# Altium schematic sheet SFP_SATA.SchDoc(SFP_SATA)
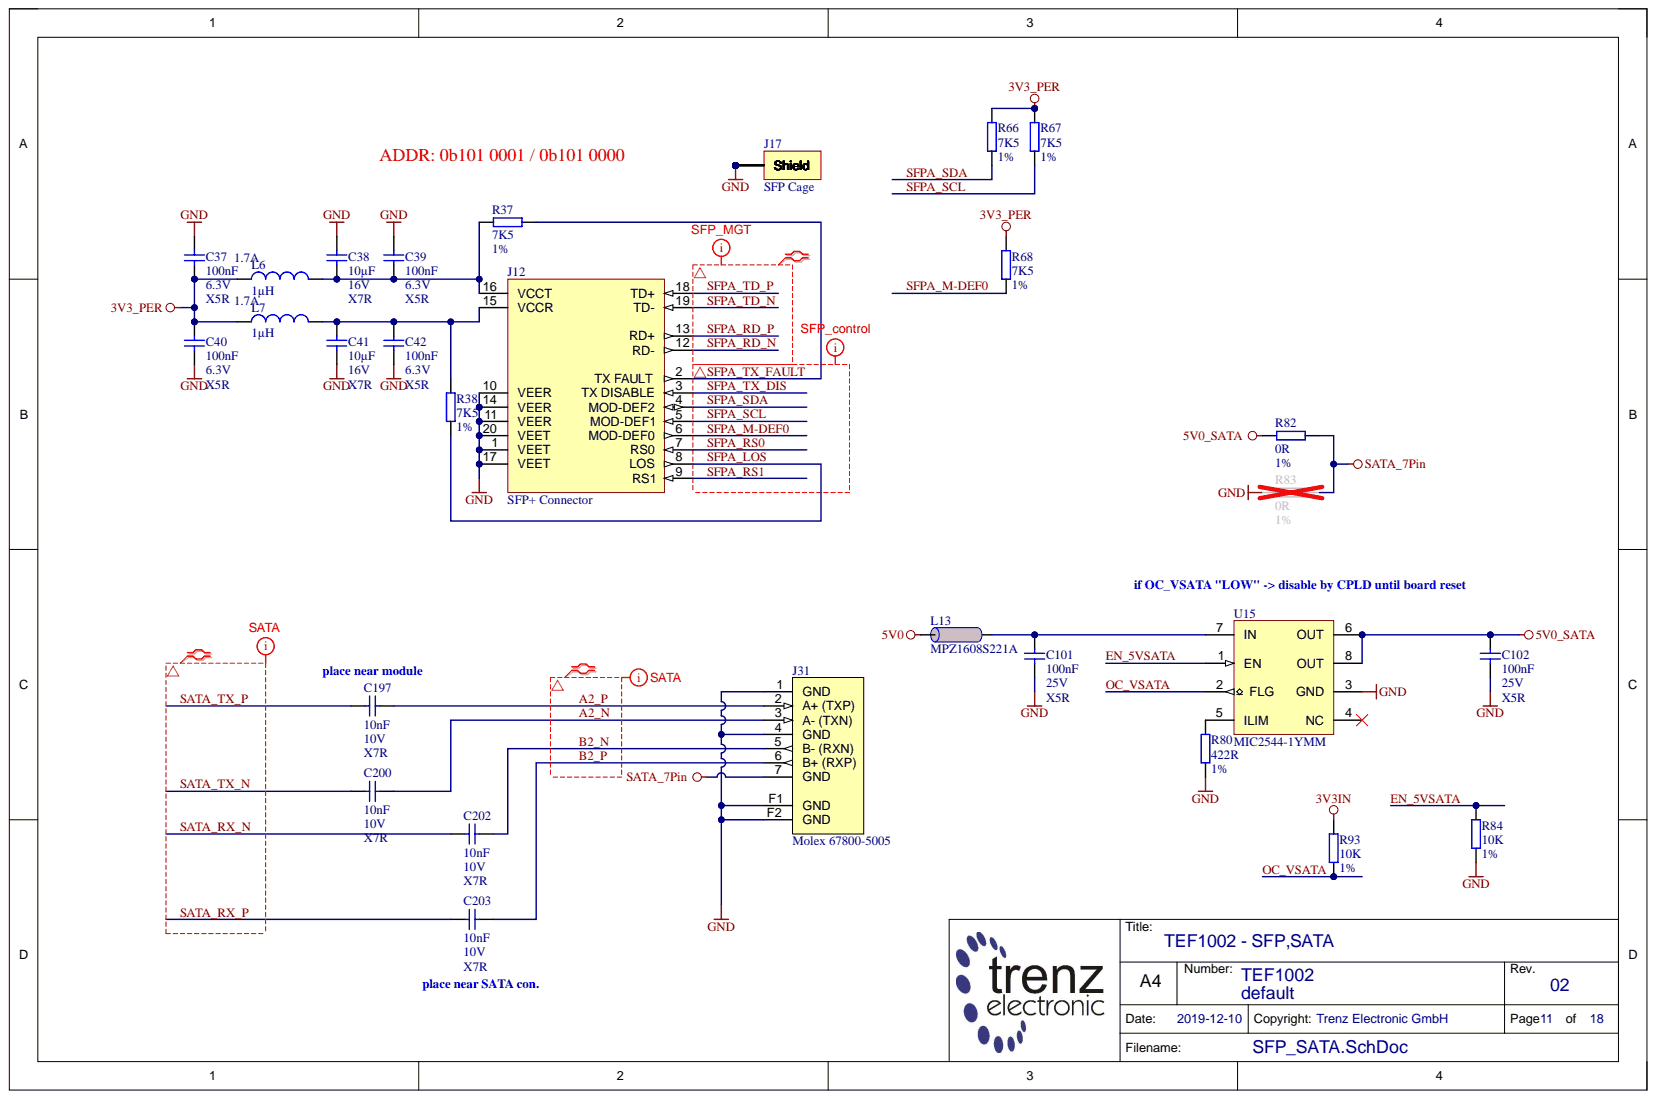
[diagram p0: SFP_SATA.SchDoc]

## Parts
C37  100nF  Technology: X5R  Voltage: 6.3V  [CAPC0603X33N]
C38  10µF  Technology: X7R  Voltage: 16V  [CAPC2012X135N]
C39  100nF  Technology: X5R  Voltage: 6.3V  [CAPC0603X33N]
C40  100nF  Technology: X5R  Voltage: 6.3V  [CAPC0603X33N]
C41  10µF  Technology: X7R  Voltage: 16V  [CAPC2012X135N]
C42  100nF  Technology: X5R  Voltage: 6.3V  [CAPC0603X33N]
C101  100nF  Technology: X5R  Voltage: 25V  [CAPC1005X55N]
C102  100nF  Technology: X5R  Voltage: 25V  [CAPC1005X55N]
C197  10nF  Voltage: 10V  [CAPC0603X33N]
C200  10nF  Technology: X7R  Voltage: 10V  [CAPC0603X33N]
C202  10nF  Voltage: 10V  [CAPC0603X33N]
C203  10nF  Technology: X7R  Voltage: 10V  [CAPC0603X33N]
J12  SFP+ Connector  [SFP Connector]
J17  SFP Cage  [SFP Cage]
J31  Molex 67800-5005  [67800-5005]
L6  1µH  Voltage: 1.7A  [INDC2520X100N]
L7  1µH  Voltage: 1.7A  [INDC2520X100N]
L13  MPZ1608S221A  [INDC1608X95N]
R37  7K5  [RESC1005X40N]
R38  7K5  Tolerance: 1%  [RESC1005X40N]
R66  7K5  Tolerance: 1%  [RESC1005X40N]
R67  7K5  Tolerance: 1%  [RESC1005X40N]
R68  7K5  Tolerance: 1%  [RESC1005X40N]
R80  422R  Tolerance: 1%  [RESC1005X40N]
R82  0R  [RESC2012X60N]
R83  0R  Tolerance: 1%  [RESC2012X60N]
R84  10K  Tolerance: 1%  [RESC1005X40N]
R93  10K  Tolerance: 1%  [RESC1005X40N]
U15  MIC2544-1YMM  [SOP65P490X110-8N]

## Nets
3V3_PER: C37.2 C40.1 L6.1 L7.1 R66.1 R67.1 R68.1
3V3IN: R93.2
5V0: L13.2
5V0_SATA: C102.2 R82.1 U15.6(OUT) U15.8(OUT)
GND: C37.1 C38.1 C39.1 C40.2 C41.2 C42.2 C101.1 C102.1 J12.1(VEET) J12.10(VEER) J12.11(VEER) J12.14(VEER) J12.17(VEET) J12.20(VEET) J17.C1(Shield) J17.C2 J17.C3 J17.C4 J17.C5 J17.C6 J17.C7 J17.C8 J17.C9 J17.C10 J17.C11 J17.C12 J17.C13 J17.C14 J17.C15 J17.C16 J17.C17 J17.C18 J17.C19 J17.C20 J31.1(GND) J31.4(GND) J31.F1(GND) J31.F2(GND) R80.2 R83.1 R84.2 U15.3(GND)
SATA_7Pin: J31.7(GND) R82.2 R83.2
A2_N: C200.2 J31.3(A-_(TXN))
A2_P: C197.2 J31.2(A+_(TXP))
B2_N: C202.2 J31.5(B-_(RXN))
B2_P: C203.2 J31.6(B+_(RXP))
EN_5VSATA: R84.1 U15.1(EN)
OC_VSATA: R93.1 U15.2(FLG)
SATA_RX_N: C202.1
SATA_RX_P: C203.1
SATA_TX_N: C200.1
SATA_TX_P: C197.1
SFPA_LOS: J12.8(LOS) R38.2
SFPA_M-DEF0: J12.6(MOD-DEF0) R68.2
SFPA_RD_N: J12.12(RD-)
SFPA_RD_P: J12.13(RD+)
SFPA_RS0: J12.7(RS0)
SFPA_RS1: J12.9(RS1)
SFPA_SCL: J12.5(MOD-DEF1) R67.2
SFPA_SDA: J12.4(MOD-DEF2) R66.2
SFPA_TD_N: J12.19(TD-)
SFPA_TD_P: J12.18(TD+)
SFPA_TX_DIS: J12.3(TX_DISABLE)
SFPA_TX_FAULT: J12.2(TX_FAULT) R37.2
NetC38_2: C38.2 C39.2 J12.16(VCCT) L6.2 R37.1
NetC41_1: C41.1 C42.1 J12.15(VCCR) L7.2 R38.1
NetC101_2: C101.2 L13.1 U15.7(IN)
NetR80_1: R80.1 U15.5(ILIM)

Not connected: U15 4(NC)

## Library data of the parts
C37 C39 C40 C42 = 24915: KON 100nF 6.3V X5R 10% SMD BF 0603 (0201); Database Table Name: C_0603_0201; Mixed: SMT; Preferred: Yes; Temperature-Range: -55/+85; Value: 100n; AN: 24915; Case: 0603 (0201); Component Kind: Standard; Library Name: TE.DbLib; Tolerance: 10%
C38 C41 = 24380: KON 10µF 16V X7R 10% SMD BF 2012 (0805); Database Table Name: C_2012_0805; Mixed: SMT; Preferred: Yes; Temperature-Range: -55/+125; Value: 10u; AN: 24380; Case: 2012 (0805); Component Kind: Standard; Library Name: TE.DbLib; Tolerance: 10%
C101 C102 = 22472: KON 100nF 25V X5R ±10% SMD BF 1005 (0402); Database Table Name: C_1005_0402; Mixed: SMT; Preferred: Yes; Temperature-Range: -55/+85; Value: 100n; AN: 22472; Case: 1005 (0402); Component Kind: Standard; Library Name: TE.DbLib
C197 C200 C202 C203 = 26312: KON 10nF 10V X7R 10% SMD BF 0603 (0201); Database Table Name: C_0603_0201; Mixed: SMT; Preferred: Yes; Temperature-Range: -55/+125; Value: 10n; AN: 26312; Case: 0603 (0201); Component Kind: Standard; Library Name: TE.DbLib; Tolerance: 10%
  C197 C202: Technology: X7R
J12 = 25303: STE SFP+ Connector 20 pol. SMD; Database Table Name: CON_IO; H-ART: 74441-0001; Mixed: SMT; Trenz-Stock: Yes; AN: 25303; Component Kind: Standard; Library Name: TE.DbLib; HelpURL: B:\AD\adlib\datasheet\SFPP
J17 = 25302: STE SFP Cage Pressfit; Database Table Name: CON_IO; H-ART: 74737-0009; Mixed: MECH; Trenz-Stock: Yes; AN: 25302; Component Kind: Standard; Library Name: TE.DbLib; HelpURL: B:\AD\adlib\datasheet\747370009
J31 = 25614: SATA 7Pol. Connector Vertical; Database Table Name: CON_IO; Mixed: SMT; AN: 25614; Component Kind: Standard; H-ART: 67800-5005; Library Name: TE.DbLib; HelpURL: B:\AD\adlib\datasheet\67800-5005
L6 L7 = 25265: IND SMD 1µH +-20% 0.055Ohm; Database Table Name: L_SMD; H-ART: 74479787210a; Mixed: SMT; Temperature-Range: -40/+85; Trenz-Stock: Yes; Value: 1u; AN: 25265; Case: WE-PMI 2520(1008); Component Kind: Standard; Library Name: TE.DbLib; Technology: 0.055Ohm; HelpURL: B:\AD\adlib\datasheet\74479787210a
L13 = 22718: Ferritdurchführung MPZ1608S221A; Database Table Name: L_1608_0603; Mixed: SMT; Preferred: Yes; Value: 220 OHm @ 100MHz; AN: 22718; Case: 1608 (0603); Component Kind: Standard; H-ART: MPZ1608S221A; Library Name: TE.DbLib; Trenz-Stock: Yes; HelpURL: B:\AD\adlib\datasheet\MPZ1608
R37 R38 R66-R68 = 26469: WID SMD 7K5 1% 0,063W; Database Table Name: R_1005_0402; Mixed: SMT; AN: 26469; Case: 1005 (0402); Component Kind: Standard; Library Name: TE.DbLib; Technology: TK100; Value: 7K5
  R37: Tolerance: 1%
R80 = 23723: WID SMD 422R 1% 0,063W  BF 1005 (0402); Database Table Name: R_1005_0402; Mixed: SMT; Preferred: Yes; Value: 422; AN: 23723; Case: 1005 (0402); Component Kind: Standard; Library Name: TE.DbLib; Technology: TK100
R82 = 23415: WID SMD 0R 0.125W 2A Brücke; Database Table Name: R_2012_0805; Mixed: SMT; Preferred: Yes; Tolerance: 1%; Value: 0; AN: 23415; Case: 2012 (0805); Component Kind: Standard; Library Name: TE.DbLib; Technology: TK100
R83 = 23415: WID SMD 0R 0.125W 2A Brücke; Database Table Name: R_2012_0805; Mixed: SMT; Preferred: Yes; Value: 0; AN: 23415; Case: 2012 (0805); Component Kind: Standard; Library Name: TE.DbLib; Technology: TK100
R84 R93 = 22750: WID SMD 10K 1% 0,063W BF 1005 (0402); Database Table Name: R_1005_0402; H-ART: RC0402FR-0710KL; Mixed: SMT; Preferred: Yes; AN: 22750; Case: 1005 (0402); Component Kind: Standard; Library Name: TE.DbLib; Technology: TK100; Value: 10K
U15 = 30005: Database Table Name: SWITCH; Mixed: SMT; Temperature-Range: -40/+85; AN: 30005; Case: MSOP8; Component Kind: Standard; Description: MIC2544-1YMM; H-ART: MIC2544-1YMM; Library Name: TE.DbLib; Storage-Temperature: -65/+150; HelpURL: b:\AD\adlib\datasheet\Microchip\MIC2544_48\
